# Altium schematic sheet 15 Audio.SchDoc
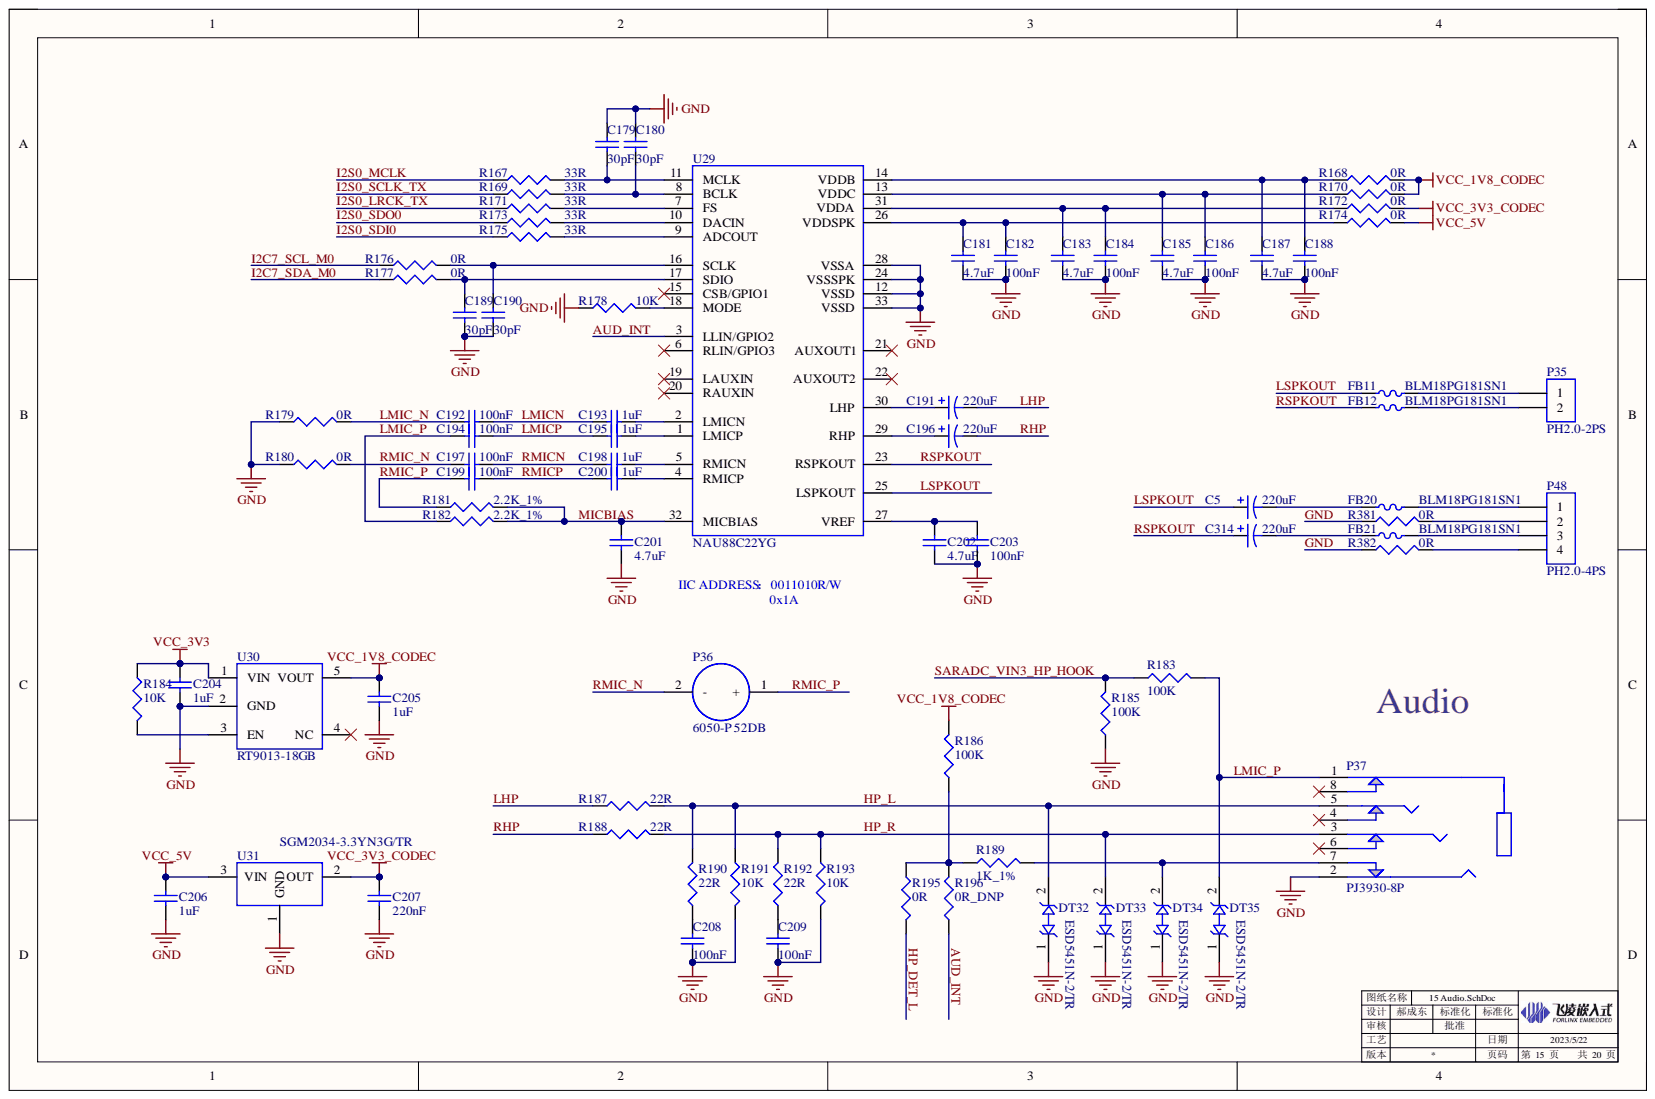
[diagram p0: 15 Audio.SchDoc]

## Parts
C5  220uF  [TC3528B]
C179  30pF  [0402C]
C180  30pF  [0402C]
C181  4.7uF  [0402C]
C182  100nF  [0402C]
C183  4.7uF  [0402C]
C184  100nF  [0402C]
C185  4.7uF  [0402C]
C186  100nF  [0402C]
C187  4.7uF  [0402C]
C188  100nF  [0402C]
C189  30pF  [0402C]
C190  30pF  [0402C]
C191  220uF  [TC3528B]
C192  100nF  [0402C]
C193  1uF  [0402C]
C194  100nF  [0402C]
C195  1uF  [0402C]
C196  220uF  [TC3528B]
C197  100nF  [0402C]
C198  1uF  [0402C]
C199  100nF  [0402C]
C200  1uF  [0402C]
C201  4.7uF  [0402C]
C202  4.7uF  [0402C]
C203  100nF  [0402C]
C204  1uF  [0402C]
C205  1uF  [0402C]
C206  1uF  [0402C]
C207  220nF  [0402C]
C208  100nF  [0402C]
C209  100nF  [0402C]
C314  220uF  [TC3528B]
DT32  ESD5451N-2/TR  [0402TVS]
DT33  ESD5451N-2/TR  [0402TVS]
DT34  ESD5451N-2/TR  [0402TVS]
DT35  ESD5451N-2/TR  [0402TVS]
FB11  BLM18PG181SN1  [0603L]
FB12  BLM18PG181SN1  [0603L]
FB20  BLM18PG181SN1  [0603L]
FB21  BLM18PG181SN1  [0603L]
P35  PH2.0-2PS  [PH2.0_2P_V]
P36  6050-P 52DB  [MIC 2*5]
P37  PJ3930-8P  [PJ3930-8P]
P48  PH2.0-4PS  [PH2.0_4P_V]
R167  33R  [0402R]
R168  0R  [0603R]
R169  33R  [0402R]
R170  0R  [0603R]
R171  33R  [0402R]
R172  0R  [0603R]
R173  33R  [0402R]
R174  0R  [0603R]
R175  33R  [0402R]
R176  0R  [0402R]
R177  0R  [0402R]
R178  10K  [0402R]
R179  0R  [0402R]
R180  0R  [0402R]
R181  2.2K_1%  [0402R]
R182  2.2K_1%  [0402R]
R183  100K  [0402R]
R184  10K  [0402R]
R185  100K  [0402R]
R186  100K  [0402R]
R187  22R  [0402R]
R188  22R  [0402R]
R189  1K_1%  [0402R]
R190  22R  [0402R]
R191  10K  [0402R]
R192  22R  [0402R]
R193  10K  [0402R]
R195  0R  [0402R]
R196  0R_DNP  [0402R]
R381  0R  [0603R]
R382  0R  [0603R]
U29  NAU88C22YG  [QFN32P_0.5BSC_5*5_H_3..65*3.65]
U30  RT9013-18GB  [SOT_235]
U31  SGM2034-3.3YN3G/TR  [SOT_233_N]

## Nets
VCC_1V8_CODEC: C205.2 R168.2 R170.2 R186.2 U30.5(VOUT)
VCC_3V3: C204.2 R184.1 U30.1(VIN)
VCC_3V3_CODEC: C207.2 R172.2 U31.2
VCC_5V: C206.2 R174.2 U31.3
AUD_INT: R196.1 U29.3(LLIN/GPIO2)
GND: C179.2 C180.2 C181.1 C182.1 C183.1 C184.1 C185.1 C186.1 C187.1 C188.1 C189.1 C190.1 C201.1 C202.1 C203.1 C204.1 C205.1 C206.1 C207.1 C208.1 C209.1 DT32.1 DT33.1 DT34.1 DT35.1 P37.2 R178.1 R179.1 R180.1 R185.1 R191.2 R193.2 R381.1 R382.1 U29.12(VSSD) U29.24(VSSSPK) U29.28(VSSA) U29.33(VSSD) U30.2(GND) U31.1
HP_DET_L: R195.2
HP_L: DT32.2 P37.5 R187.2 R190.2 R191.1
HP_R: DT33.2 P37.3 R188.2 R192.2 R193.1
I2C7_SCL_M0: R176.1
I2C7_SDA_M0: R177.1
I2S0_LRCK_TX: R171.1
I2S0_MCLK: R167.1
I2S0_SCLK_TX: R169.1
I2S0_SDI0: R175.1
I2S0_SDO0: R173.1
LHP: C191.2 R187.1
LMIC_N: C192.1 R179.2
LMIC_P: C194.1 DT35.2 P37.1 R182.1 R183.2
LMICN: C192.2 C193.1
LMICP: C194.2 C195.1
LSPKOUT: C5.1 FB11.1 U29.25(LSPKOUT)
MICBIAS: C201.2 R181.2 R182.2 U29.32(MICBIAS)
RHP: C196.2 R188.1
RMIC_N: C197.1 P36.2 R180.2
RMIC_P: C199.1 P36.1 R181.1
RMICN: C197.2 C198.1
RMICP: C199.2 C200.1
RSPKOUT: C314.1 FB12.1 U29.23(RSPKOUT)
SARADC_VIN3_HP_HOOK: R183.1 R185.2
NetC5_2: C5.2 FB20.1
NetC179_1: C179.1 R167.2 U29.11(MCLK)
NetC180_1: C180.1 R169.2 U29.8(BCLK)
NetC181_2: C181.2 C182.2 R174.1 U29.26(VDDSPK)
NetC183_2: C183.2 C184.2 R172.1 U29.31(VDDA)
NetC185_2: C185.2 C186.2 R170.1 U29.13(VDDC)
NetC187_2: C187.2 C188.2 R168.1 U29.14(VDDB)
NetC189_2: C189.2 R177.2 U29.17(SDIO)
NetC190_2: C190.2 R176.2 U29.16(SCLK)
NetC191_1: C191.1 U29.30(LHP)
NetC193_2: C193.2 U29.2(LMICN)
NetC195_2: C195.2 U29.1(LMICP)
NetC196_1: C196.1 U29.29(RHP)
NetC198_2: C198.2 U29.5(RMICN)
NetC200_2: C200.2 U29.4(RMICP)
NetC202_2: C202.2 C203.2 U29.27(VREF)
NetC208_2: C208.2 R190.1
NetC209_2: C209.2 R192.1
NetC314_2: C314.2 FB21.1
NetDT34_2: DT34.2 P37.7 R189.2
NetFB11_2: FB11.2 P35.1
NetFB12_2: FB12.2 P35.2
NetFB20_2: FB20.2 P48.1
NetFB21_2: FB21.2 P48.3
NetP48_2: P48.2 R381.2
NetP48_4: P48.4 R382.2
NetR171_2: R171.2 U29.7(FS)
NetR173_2: R173.2 U29.10(DACIN)
NetR175_2: R175.2 U29.9(ADCOUT)
NetR178_2: R178.2 U29.18(MODE)
NetR184_2: R184.2 U30.3(EN)
NetR186_1: R186.1 R189.1 R195.1 R196.2

Not connected: P37 4 6 8; U29 6(RLIN/GPIO3) 15(CSB/GPIO1) 19(LAUXIN) 20(RAUXIN) 21(AUXOUT1) 22(AUXOUT2); U30 4(NC)

## Library data of the parts
C5 C191 C196 C314 = 1110209006: Database Table Name: VALID$; 存货名称: 贴装钽电容; 序号: 829; 规格型号: B型,220uF,6.3V; Component Kind: Standard; LatestRevisionDate: 17-Jul-2002; LatestRevisionNote: Re-released for DXP Platform.; Library Name: Database_AD Library.DbLib; PackageDescription: Polarized Capacitor (Radial); 2 Leads; PackageReference: RB7.6-15; Published: 8-Jun-2000; Signal Integrity: Cap Pol; Simulation: CAP; Value: 220uF; 存货编码: 1110209006; Publisher: Altium Limited
C179 C180 C189 C190 = 1110202045: Database Table Name: VALID$; 存货名称: 贴装叠层陶瓷电容; 规格型号: 0402,30pF,50V,±5%,NPO; Component Kind: Standard; LatestRevisionDate: 17-Jul-2002; LatestRevisionNote: Re-released for DXP Platform.; Library Name: Database_AD Library.DbLib; PackageDescription: Radial Cap, Thru-Hole; 2 Leads; 0.3 in Pin Spacing; PackageReference: RAD-0.3; Published: 8-Jun-2000; Signal Integrity: Cap; Simulation: CAP; Value: 30pF; 存货编码: 1110202045; Publisher: Altium Limited
C181 C183 C185 C187 C201 C202 = 1110202032: Database Table Name: VALID$; 存货名称: 贴装叠层陶瓷电容; 序号: 616; 规格型号: 0402,4.7uF,10V,±10％,X5R; Component Kind: Standard; LatestRevisionDate: 17-Jul-2002; LatestRevisionNote: Re-released for DXP Platform.; Library Name: Database_AD Library.DbLib; PackageDescription: Radial Cap, Thru-Hole; 2 Leads; 0.3 in Pin Spacing; PackageReference: RAD-0.3; Published: 8-Jun-2000; Signal Integrity: Cap; Simulation: CAP; Value: 4.7uF; 存货编码: 1110202032; Publisher: Altium Limited
C182 C184 C186 C188 C192 C194 C197 C199 C203 C208 C209 = 1110202039: Database Table Name: VALID$; 存货名称: 贴装叠层陶瓷电容; 序号: 626; 规格型号: 0402,100nF,25V,±10％,X5R; Component Kind: Standard; LatestRevisionDate: 17-Jul-2002; LatestRevisionNote: Re-released for DXP Platform.; Library Name: Database_AD Library.DbLib; PackageDescription: Radial Cap, Thru-Hole; 2 Leads; 0.3 in Pin Spacing; PackageReference: RAD-0.3; Published: 8-Jun-2000; Signal Integrity: Cap; Simulation: CAP; Value: 100nF; 存货编码: 1110202039; Publisher: Altium Limited
C193 C195 C198 C200 C204-C206 = 1110202021: Database Table Name: VALID$; 存货名称: 贴装叠层陶瓷电容; 序号: 600; 规格型号: 0402,1uF,16V,±10％,X5R; Component Kind: Standard; LatestRevisionDate: 17-Jul-2002; LatestRevisionNote: Re-released for DXP Platform.; Library Name: Database_AD Library.DbLib; PackageDescription: Radial Cap, Thru-Hole; 2 Leads; 0.3 in Pin Spacing; PackageReference: RAD-0.3; Published: 8-Jun-2000; Signal Integrity: Cap; Simulation: CAP; Value: 1uF; 存货编码: 1110202021; Publisher: Altium Limited
C207 = 1110202042: Database Table Name: VALID$; 存货名称: 贴装叠层陶瓷电容; 规格型号: 0402,220nF,25V,±10％,X5R; Component Kind: Standard; LatestRevisionDate: 17-Jul-2002; LatestRevisionNote: Re-released for DXP Platform.; Library Name: Database_AD Library.DbLib; PackageDescription: Radial Cap, Thru-Hole; 2 Leads; 0.3 in Pin Spacing; PackageReference: RAD-0.3; Published: 8-Jun-2000; Signal Integrity: Cap; Simulation: CAP; Value: 220nF; 存货编码: 1110202042; Publisher: Altium Limited
DT32-DT35 = 1110503036: Database Table Name: VALID$; 存货名称: TVS管; 规格型号: ESD5451N-2/TR,DFN1006-2L; Component Kind: Standard; Library Name: Database_AD Library.DbLib; Value: ESD5451N-2/TR; 存货编码: 1110503036
FB11 FB12 FB20 FB21 = 1110304016: Database Table Name: VALID$; 存货名称: 磁珠; 序号: 1042; 规格型号: 0603,180 Ohm@100MHz,1.5A,BLM18PG181SN1; Component Kind: Standard; Library Name: Database_AD Library.DbLib; VALUE: BLM18PG181SN1; 存货编码: 1110304016
P35 = 1120201007: Database Table Name: VALID$; 存货名称: 插装连接器; 序号: 1261; 规格型号: PH,公头,2P,2.0mm,S; Component Kind: Standard; LatestRevisionDate: 17-Jul-2002; LatestRevisionNote: Re-released for DXP Platform.; Library Name: Database_AD Library.DbLib; Signal Integrity: Connector; Value: PH2.0-2PS; 存货编码: 1120201007; Publisher: Altium Limited
P36 = 1120202094: Database Table Name: VALID$; 存货名称: 话筒; 序号: 4063; 描述栏信息: 咪头; 禁用状态: 否; 规格型号: 6050-P 52DB 带引脚; Component Kind: Standard; Library Name: Database_AD Library.DbLib; value: 6050-P 52DB; 存货编码: 1120202094
P37 = PJ3930-8P: 3.5mm音频4级耳机插座，SMT贴片; Component Kind: Standard; Library Name: Schlib1.SchLib
P48 = 1120201008: Database Table Name: VALID$; 存货名称: 插装连接器; 序号: 1262; 规格型号: PH,公头,4P,2.0mm,S; Component Kind: Standard; LatestRevisionDate: 17-Jul-2002; LatestRevisionNote: Re-released for DXP Platform.; Library Name: Database_AD Library.DbLib; Signal Integrity: Connector; Value: PH2.0-4PS; 存货编码: 1120201008; Publisher: Altium Limited
R167 R169 R171 R173 R175 = 1110102027: Database Table Name: VALID$; 存货名称: 贴装电阻; 序号: 38; 规格型号: 0402,33Ω,±5％; Component Kind: Standard; Library Name: Database_AD Library.DbLib; Signal Integrity: Res; Simulation: RESISTOR; Value: 33R; 存货编码: 1110102027
R168 R170 R172 R174 R381 R382 = 1110103004: Database Table Name: VALID$; 存货名称: 贴装电阻; 序号: 172; 规格型号: 0603,0Ω,±5％; Component Kind: Standard; Library Name: Database_AD Library.DbLib; Signal Integrity: Res; Simulation: RESISTOR; Value: 0R; 存货编码: 1110103004
R176 R177 R179 R180 R195 R196 = 1110102001: Database Table Name: VALID$; 存货名称: 贴装电阻; 序号: 10; 规格型号: 0402,0Ω,±5％; Component Kind: Standard; Library Name: Database_AD Library.DbLib; Signal Integrity: Res; Simulation: RESISTOR; 存货编码: 1110102001
  R176 R177 R179 R180 R195: Value: 0R
  R196: Value: 0R_DNP
R178 R184 R191 R193 = 1110102007: Database Table Name: VALID$; 存货名称: 贴装电阻; 序号: 17; 规格型号: 0402,10K,±5％; Component Kind: Standard; Library Name: Database_AD Library.DbLib; Signal Integrity: Res; Simulation: RESISTOR; Value: 10K; 存货编码: 1110102007
R181 R182 = 1110102038: Database Table Name: VALID$; 存货名称: 贴装电阻; 序号: 51; 规格型号: 0402,2.2K,±1％; Component Kind: Standard; Library Name: Database_AD Library.DbLib; Signal Integrity: Res; Simulation: RESISTOR; Value: 2.2K_1%; 存货编码: 1110102038
R183 R185 R186 = 1110102004: Database Table Name: VALID$; 存货名称: 贴装电阻; 序号: 14; 规格型号: 0402,100K,±5％; Component Kind: Standard; Library Name: Database_AD Library.DbLib; Signal Integrity: Res; Simulation: RESISTOR; Value: 100K; 存货编码: 1110102004
R187 R188 R190 R192 = 1110102028: Database Table Name: VALID$; 存货名称: 贴装电阻; 序号: 41; 规格型号: 0402,22Ω,±5％; Component Kind: Standard; Library Name: Database_AD Library.DbLib; Signal Integrity: Res; Simulation: RESISTOR; Value: 22R; 存货编码: 1110102028
R189 = 1110102011: Database Table Name: VALID$; 存货名称: 贴装电阻; 序号: 21; 规格型号: 0402,1K,±1％; Component Kind: Standard; Library Name: Database_AD Library.DbLib; Signal Integrity: Res; Simulation: RESISTOR; Value: 1K_1%; 存货编码: 1110102011
U29 = 1110405394: Database Table Name: VALID$; 存货名称: 常规IC; 规格型号: NAU88C22YG,QFN32; Component Kind: Standard; Library Name: Database_AD Library.DbLib; value: NAU88C22YG; 存货编码: 1110405394
U30 = 1110404097: Database Table Name: VALID$; 存货名称: 电源; 序号: 2093; 规格型号: RT9013-18GB,SOT-23-5; Component Kind: Standard; Library Name: Database_AD Library.DbLib; Value: RT9013-18GB; 存货编码: 1110404097
U31 = 1110404126: Database Table Name: VALID$; 存货名称: 电源; 描述栏信息: 替代1110404068，备件为1110404151; 规格型号: SGM2034-3.3YN3G/TR,SOT-23-3; Component Kind: Standard; Library Name: Database_AD Library.DbLib; Value: SGM2034-3.3YN3G/TR; 存货编码: 1110404126
